# Altium schematic sheet Top.SchDoc
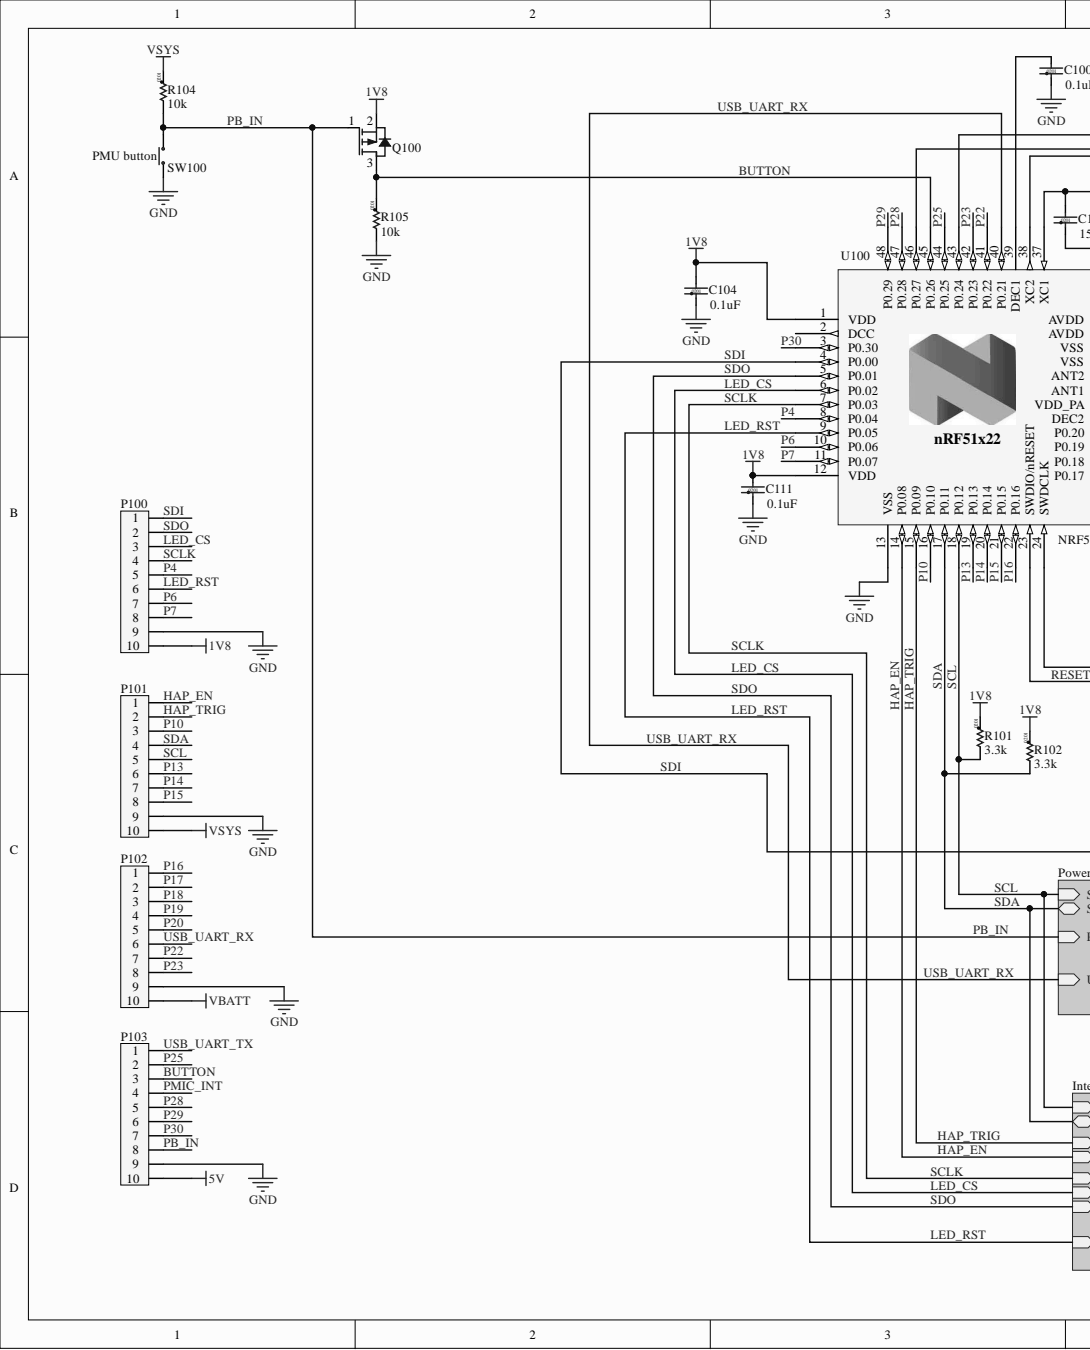
[diagram p0: Top.SchDoc, left]
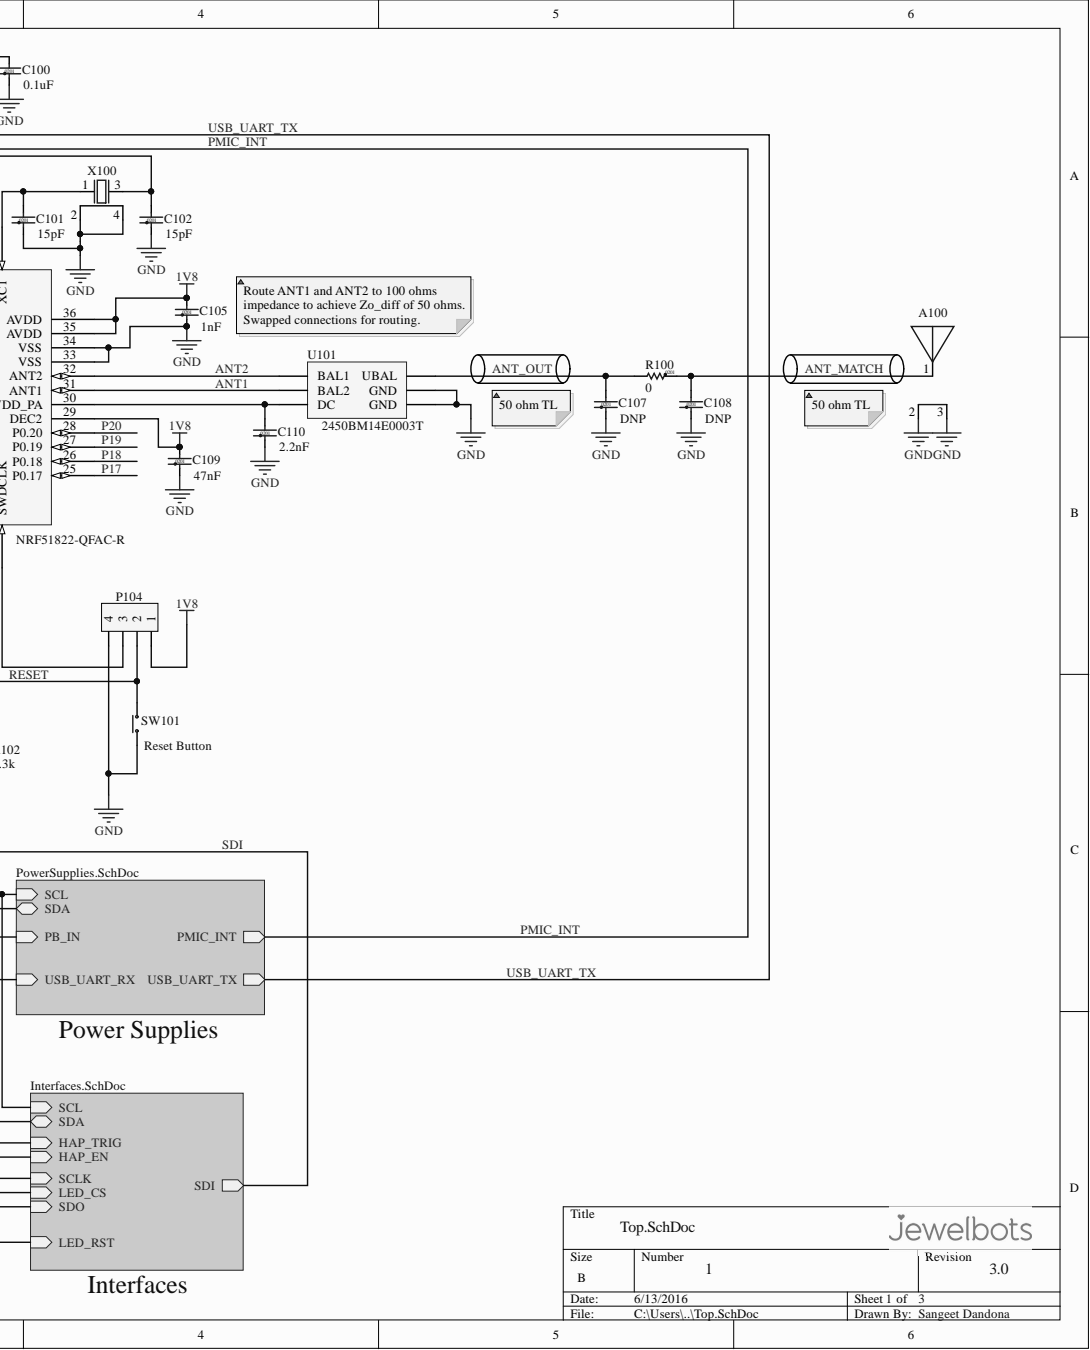
[diagram p1: Top.SchDoc, right]

## Parts
A100  Part Number  [2450AT07A0100]  (value not drawn)
C100  value 0.1uF  Size: 0201  [Cap 0201 HD]  (hidden comment: Part Number0.1uFDNP)
C101  value 15pF  Size: 0201  [Cap 0201 HD]  (hidden comment: Part Number15pFDNP)
C102  value 15pF  Size: 0201  [Cap 0201 HD]  (hidden comment: Part Number15pFDNP)
C104  value 0.1uF  Size: 0201  [Cap 0201 HD]  (hidden comment: Part Number0.1uFDNP)
C105  value 1nF  Size: 0201  [Cap 0201 HD]  (hidden comment: Part Number1nFDNP)
C107  value DNP  MFPN: DNP  Size: 0201  [Cap 0201 HD]  (hidden comment: Part NumberDNPDNP)
C108  value DNP  MFPN: DNP  Size: 0201  [Cap 0201 HD]  (hidden comment: Part NumberDNPDNP)
C109  value 47nF  Size: 0201  [Cap 0201 HD]  (hidden comment: Part Number47nFDNP)
C110  value 2.2nF  Size: 0201  [Cap 0201 HD]  (hidden comment: Part Number2.2nFDNP)
C111  value 0.1uF  Size: 0201  [Cap 0201 HD]  (hidden comment: Part Number0.1uFDNP)
P100  Value  [Molex 15-91-2100]  (value not drawn)
P101  Value  [Molex 15-91-2100]  (value not drawn)
P102  Value  [Molex 15-91-2100]  (value not drawn)
P103  Value  [Molex 15-91-2100]  (value not drawn)
P104  Value  [LIST_1x4_SMD]  (value not drawn)
Q100  Part Number  [SOT-723]  (value not drawn)
R100  value 0  Size: 0201  [RES 0201 HD]  (hidden comment: Part Number0DNP)
R101  value 3.3k  Size: 0201  [RES 0201 HD]  (hidden comment: Part Number3.3kDNP)
R102  value 3.3k  Size: 0201  [RES 0201 HD]  (hidden comment: Part Number3.3kDNP)
R104  value 10k  Size: 0201  [RES 0201 HD]  (hidden comment: Part Number10kDNP)
R105  value 10k  Size: 0201  [RES 0201 HD]  (hidden comment: Part Number10kDNP)
SW100  Value  [ALPS_SKHUxxx010_WO_GND]  (value not drawn)
SW101  Value  [ALPS_SKHUxxx010_WO_GND]  (value not drawn)
U100  NRF51822-QFAC-R  [QFN40P600X600X90-48N]
U101  MFPN: 2450BM14E0003T  [2450BM14E0003]  (hidden comment: nRF51822 Balun RF filter)
X100  Part NumberDNP  [XTAL_ABM11-16]  (value not drawn)

## Nets
1V8: C104.1 C105.1 C109.1 C111.1 P100.10 P104.1 Q100.2 R101.2 R102.2 U100.1(VDD) U100.12(VDD) U100.29(DEC2) U100.35(AVDD) U100.36(AVDD)
5V: P103.10
GND: A100.2 A100.3 C100.2 C101.2 C102.2 C104.2 C105.2 C107.2 C108.2 C109.2 C110.2 C111.2 P100.9 P101.9 P102.9 P103.9 P104.4 R105.1 SW100.2 SW101.2 U100.13(VSS) U100.33(VSS) U100.34(VSS) U100.49 U101.2(GND) U101.6(GND) X100.2 X100.4
VBATT: P102.10
VSYS: P101.10 R104.2
~{LED_CS}: P100.3 U100.6(P0.02)
~{LED_RST}: P100.6 U100.9(P0.05)
~{PB_IN}: P103.8 Q100.1 R104.1 SW100.1
~{RESET}: P104.2 SW101.1 U100.23(SWDIO/nRESET)
ANT1: U100.31(ANT1) U101.4(BAL2)
ANT2: U100.32(ANT2) U101.3(BAL1)
ANT_MATCH: A100.1 C108.1 R100.2
ANT_OUT: C107.1 R100.1 U101.1(UBAL)
BUTTON: P103.3 Q100.3 R105.2 U100.45(P0.26)
HAP_EN: P101.1 U100.14(P0.08)
HAP_TRIG: P101.2 U100.15(P0.09)
P4: P100.5 U100.8(P0.04)
P6: P100.7 U100.10(P0.06)
P7: P100.8 U100.11(P0.07)
P10: P101.3 U100.16(P0.10)
P13: P101.6 U100.19(P0.13)
P14: P101.7 U100.20(P0.14)
P15: P101.8 U100.21(P0.15)
P16: P102.1 U100.22(P0.16)
P17: P102.2 U100.25(P0.17)
P18: P102.3 U100.26(P0.18)
P19: P102.4 U100.27(P0.19)
P20: P102.5 U100.28(P0.20)
P22: P102.7 U100.41(P0.22)
P23: P102.8 U100.42(P0.23)
P25: P103.2 U100.44(P0.25)
P28: P103.5 U100.47(P0.28)
P29: P103.6 U100.48(P0.29)
P30: P103.7 U100.3(P0.30)
PMIC_INT: P103.4 U100.46(P0.27)
SCL: P101.5 R101.1 U100.18(P0.12)
SCLK: P100.4 U100.7(P0.03)
SDA: P101.4 R102.1 U100.17(P0.11)
SDI: P100.1 U100.4(P0.00)
SDO: P100.2 U100.5(P0.01)
USB_UART_RX: P102.6 U100.40(P0.21)
USB_UART_TX: P103.1 U100.43(P0.24)
NetC100_1: C100.1 U100.39(DEC1)
NetC101_1: C101.1 U100.37(XC1) X100.1
NetC102_1: C102.1 U100.38(XC2) X100.3
NetC110_1: C110.1 U100.30(VDD_PA) U101.5(DC)
NetP104_3: P104.3 U100.24(SWDCLK)
NetU100_2: U100.2(DCC)

## Library data of the parts
A100 = 2450AT07A0100: ANTENNA SMD EIA 0402 2.4GHZ; Database Table Name: RF; MF: Johanson Technology Inc.; MFPN: 2450AT07A0100E; Component Kind: Standard; Cost: 0.36562; Height: 0.37mm; Size: 1mmx0.5mm; Library Name: Rigado_AltiumLib.DbLib
C100 C104 C111 = Cap 0201 0.1uF 6.3V: CAP CER 0.1UF 6.3V 10% X5R 0201; Database Table Name: Capacitors; MF: Murata Electronics North America; MFPN: GRM033R60J104KE19D; Component Kind: Standard; Cost: 0.00780; Signal Integrity: Cap Semi; Simulation: CAP; Library Name: Rigado_AltiumLib.DbLib
C101 C102 = Cap 0201 11pF 25V: CAP CER 15PF 25V NP0 0201; Database Table Name: Capacitors; MF: Murata Electronics North America; MFPN: GRM0335C1E150FA01D; Component Kind: Standard; Cost: 0.00900; Signal Integrity: Cap Semi; Simulation: CAP; Library Name: Rigado_AltiumLib.DbLib
C105 = Cap 0201 1nF 25V: CAP CER 1000PF 25V 10% X7R 0201; Database Table Name: Capacitors; MF: Murata Electronics North America; MFPN: GRM033R71E102KA01D; Component Kind: Standard; Cost: 0.00600; Signal Integrity: Cap Semi; Simulation: CAP; Library Name: Rigado_AltiumLib.DbLib
C107 C108 = Cap 0201 Generic: Capacitor, Ceramic 0201, DNP; Database Table Name: Capacitors; Component Kind: Standard; Cost: 0; MF: N/A; Signal Integrity: Cap Semi; Simulation: CAP; Library Name: Rigado_AltiumLib.DbLib
C109 = Cap 0201 47nF 10V: CAP CER 0.047UF 10V 10% X5R 0201; Database Table Name: Capacitors; MF: Taiyo Yuden; MFPN: LMK063BJ473KP-F; Component Kind: Standard; Cost: 0.03000; Signal Integrity: Cap Semi; Simulation: CAP; Library Name: Rigado_AltiumLib.DbLib
C110 = Cap 0201 2.2nF 16V: CAP CER 2200PF 16V 10% X7R 0201; Database Table Name: Capacitors; MF: Murata Electronics North America; MFPN: GRM033R71C222KA88D; Component Kind: Standard; Cost: 0.00630; Signal Integrity: Cap Semi; Simulation: CAP; Library Name: Rigado_AltiumLib.DbLib
P100-P103 = CONN-10: CONN HEADER 10POS .100" VERT TIN; MF: Molex, LLC; MFPN: 0015912100; Component Kind: Standard; Cost: 0.47152; Library Name: JDB-001.SCHLIB
P104 = CONN-4: BOARD-BOARD CONNECTOR HEADER, 4WAY, 1ROW; MF: GLOBAL CONNECTOR TECHNOLOGY; MFPN: BG055-04A-1-0450-0530-0350-L-G; Component Kind: Standard; Cost: 0.213; Library Name: JDB-001.SCHLIB
Q100 = MOSFET-P: MOSFET P-CH 20V 0.1A VMT3; MF: Rohm Semiconductor; MFPN: RZM001P02T2L; Component Kind: Standard; Cost: 0.22000; Package: SOT-723; Size: 1.2mm x 0.8mm; Type: MOSFET-P; Library Name: JDB-001.SCHLIB
R100 = Res 0201 0R Jumper: RES SMD 0.0OHM JUMPER 1/20W 0201; Database Table Name: Resistors; MF: Samsung Electro-Mechanics America, Inc.; MFPN: RC0603J000CS; Component Kind: Standard; Cost: 0.00787; Signal Integrity: Res; Simulation: RESISTOR; Library Name: Rigado_AltiumLib.DbLib
R101 R102 = Res 0201 3.3k 5%: RES SMD 3.3K OHM 5% 1/20W 0201; Database Table Name: Resistors; MF: Samsung Electro-Mechanics America, Inc.; MFPN: RC0603J332CS; Component Kind: Standard; Cost: 0.00648; Signal Integrity: Res; Simulation: RESISTOR; Library Name: Rigado_AltiumLib.DbLib
R104 R105 = Res 0201 10k 5%: RES SMD 10K OHM 5% 1/20W 0201; Database Table Name: Resistors; MF: Rohm Semiconductor; MFPN: MCR006YRTJ103; Component Kind: Standard; Cost: 0.00828; Signal Integrity: Res; Simulation: RESISTOR; Library Name: Rigado_AltiumLib.DbLib
SW100 SW101 = SW-PB: Tactile Switches 6.0x6.0x2.5mm 160gf; MF: ALPS; MFPN: SKHUALE010; Component Kind: Standard; Cost: 0.201; Size: 6.3mm x 6.2mm x 3.1mm; Library Name: JDB-001.SCHLIB
U100 = nRF51x22_QFAx: IC SOC 2.4GHZ 256K FLASH 48QFN; MF: Nordic Semiconductor ASA; Component Kind: Standard; Cost: 2.33310; MFPN: NRF51822-QFAC-R; Library Name: JDB-001.SCHLIB
U101 = nRF51822 Balun RF filter: NORDIC NRF51 BALUN FILTER; MF: Johanson Technology Inc.; Component Kind: Standard; Cost: 0.66000; Library Name: JDB-001.SCHLIB
X100 = XTAL_ABM11-16: CRYSTAL 16.0000MHZ 10PF SMD; MF: Abracon LLC; MFPN: ABM11-16.000MHZ-B7G-T; Component Kind: Standard; Cost: 0.60000; Size: 2.0mm x 1.6mm; Library Name: JWB-001_Main.SCHLIB
